# Altium schematic sheet NFC.SchDoc(NFC)
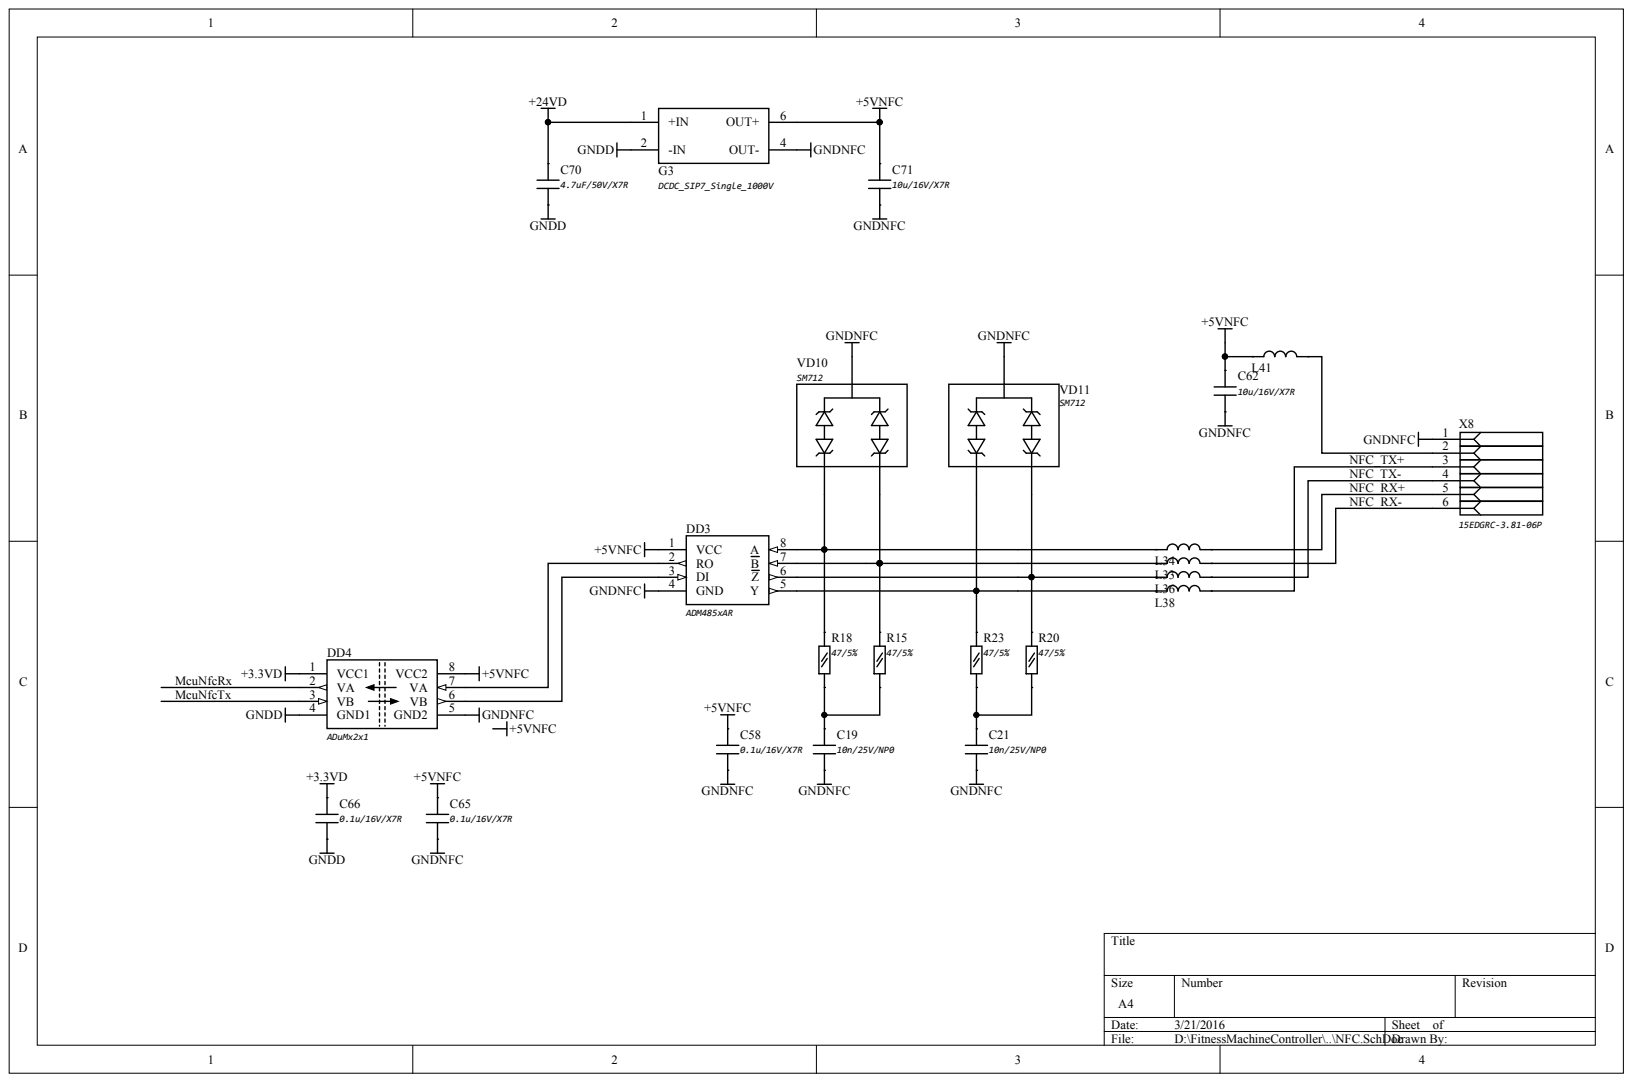
[diagram p0: NFC.SchDoc]

## Parts
C19  10n/25V/NP0
C21  10n/25V/NP0
C58  0.1u/16V/X7R
C62  10u/16V/X7R
C65  0.1u/16V/X7R
C66  0.1u/16V/X7R
C70  4.7uF/50V/X7R
C71  10u/16V/X7R
DD3  ADM485xAR
DD4  ADuMx2x1
G3  DCDC_SIP7_Single_1000V
L33  FerriteFilterBLM18  (value not drawn)
L34  FerriteFilterBLM18  (value not drawn)
L36  FerriteFilterBLM18  (value not drawn)
L38  FerriteFilterBLM18  (value not drawn)
L41  FerriteFilterBLM18  (value not drawn)
R15  47/5%
R18  47/5%
R20  47/5%
R23  47/5%
VD10  SM712
VD11  SM712
X8  15EDGRC-3.81-06P

## Nets
+3.3VD: C66.2 DD4.1(VCC1)
+5VNFC: C58.2 C62.2 C65.2 C71.2 DD3.1(VCC) DD4.8(VCC2) G3.6(OUT+) L41.1
+24VD: C70.2 G3.1(+IN)
GNDD: C66.1 C70.1 DD4.4(GND1) G3.2(-IN)
GNDNFC: C19.1 C21.1 C58.1 C62.1 C65.1 C71.1 DD3.4(GND) DD4.5(GND2) G3.4(OUT-) VD10.3 VD11.3 X8.1
MCUNFCRX: DD4.2(VA)
MCUNFCTX: DD4.3(VB)
NFC_RX+: L34.2 X8.5
NFC_RX-: L33.2 X8.6
NFC_TX+: L38.2 X8.3
NFC_TX-: L36.2 X8.4
NetC19_2: C19.2 R15.2 R18.2
NetC21_2: C21.2 R20.2 R23.2
NetDD3_2: DD3.2(RO) DD4.7(VA)
NetDD3_3: DD3.3(DI) DD4.6(VB)
NetDD3_5: DD3.5(Y) L38.1 R23.1 VD11.1
NetDD3_6: DD3.6(Z) L36.1 R20.1 VD11.2
NetDD3_7: DD3.7(B) L33.1 R15.1 VD10.1
NetDD3_8: DD3.8(A) L34.1 R18.1 VD10.2
NetL41_2: L41.2 X8.2

## Library data of the parts
C19 C21 C58 C65 C66 = (no symbol name): ComponentDescriptionRUS: Конденсатор SMD 0603; Analog: нет; NumberSolderPointsSMD: 0; NumberSolderPointsTHT: 0
C62 C71 = (no symbol name): ComponentDescriptionRUS: Конденсатор SMD 1206; Analog: нет; NumberSolderPointsSMD: 0; NumberSolderPointsTHT: 0
C70 = (no symbol name): ComponentDescriptionRUS: Конденсатор SMD 1210; Analog: нет; NumberSolderPointsSMD: 0; NumberSolderPointsTHT: 0
DD3 = (no symbol name): ComponentDescriptionRUS: Микросхема SO8; Аналог: нет; NumberSolderPointsSMD: 0; NumberSolderPointsTHT: 0
DD4 = (no symbol name): ComponentDescriptionRUS: Микросхема SO8; Analog: нет; NumberSolderPointsSMD: 0; NumberSolderPointsTHT: 0
G3 = (no symbol name): ComponentDescriptionRUS: Модуль питания; Analog: нет; NumberSolderPointsSMD: 0; NumberSolderPointsTHT: 0
L33 L34 L36 L38 L41 = (no symbol name): ComponentDescriptionRUS: Фильтр SMD 0603; Analog: нет; NumberSolderPointsSMD: 0; NumberSolderPointsTHT: 0
R15 R18 R20 R23 = (no symbol name): ComponentDescriptionRUS: Резистор SMD 0603; Analog: нет; NumberSolderPointsSMD: 0; NumberSolderPointsTHT: 0
VD10 VD11 = (no symbol name): Описание: Диод SMB; NumberSolderPointsSMD: 0; Аналог: нет; NumberSolderPointsTHT: 0
X8 = (no symbol name): Аналог: ECH381R-06P; NumberSolderPointsSMD: 0; Комплект: EC381V-06P, 15EDGK-3.81-06; NumberSolderPointsTHT: 0
